# Altium schematic sheet 5-Bank3-5.schdoc(5-Bank3-5)
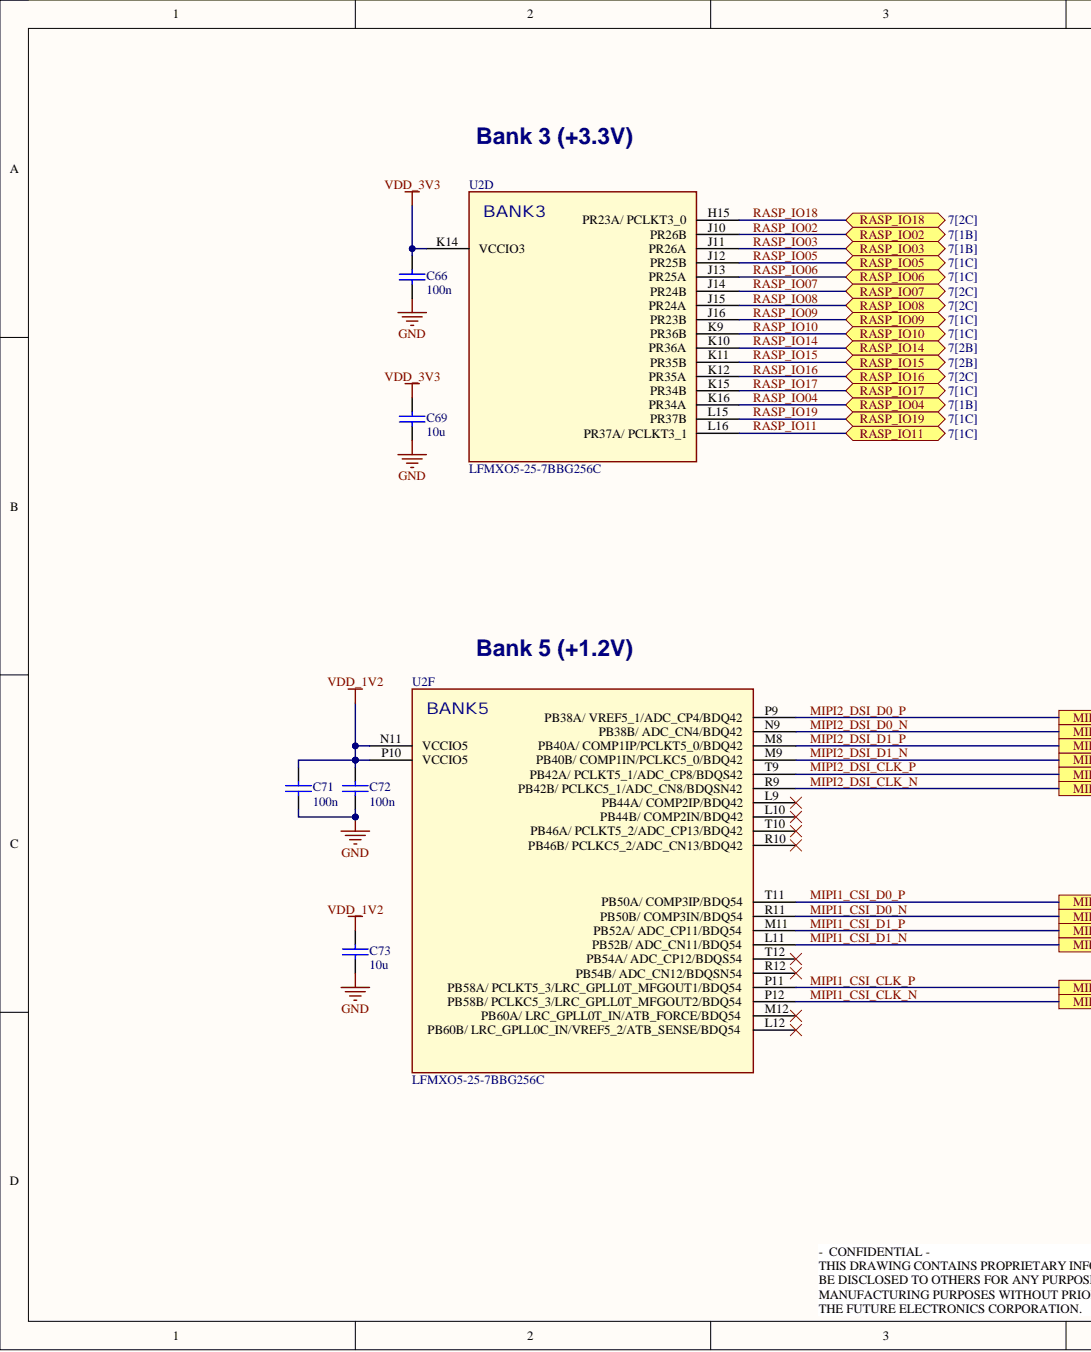
[diagram p0: 5-Bank3-5.schdoc, left]
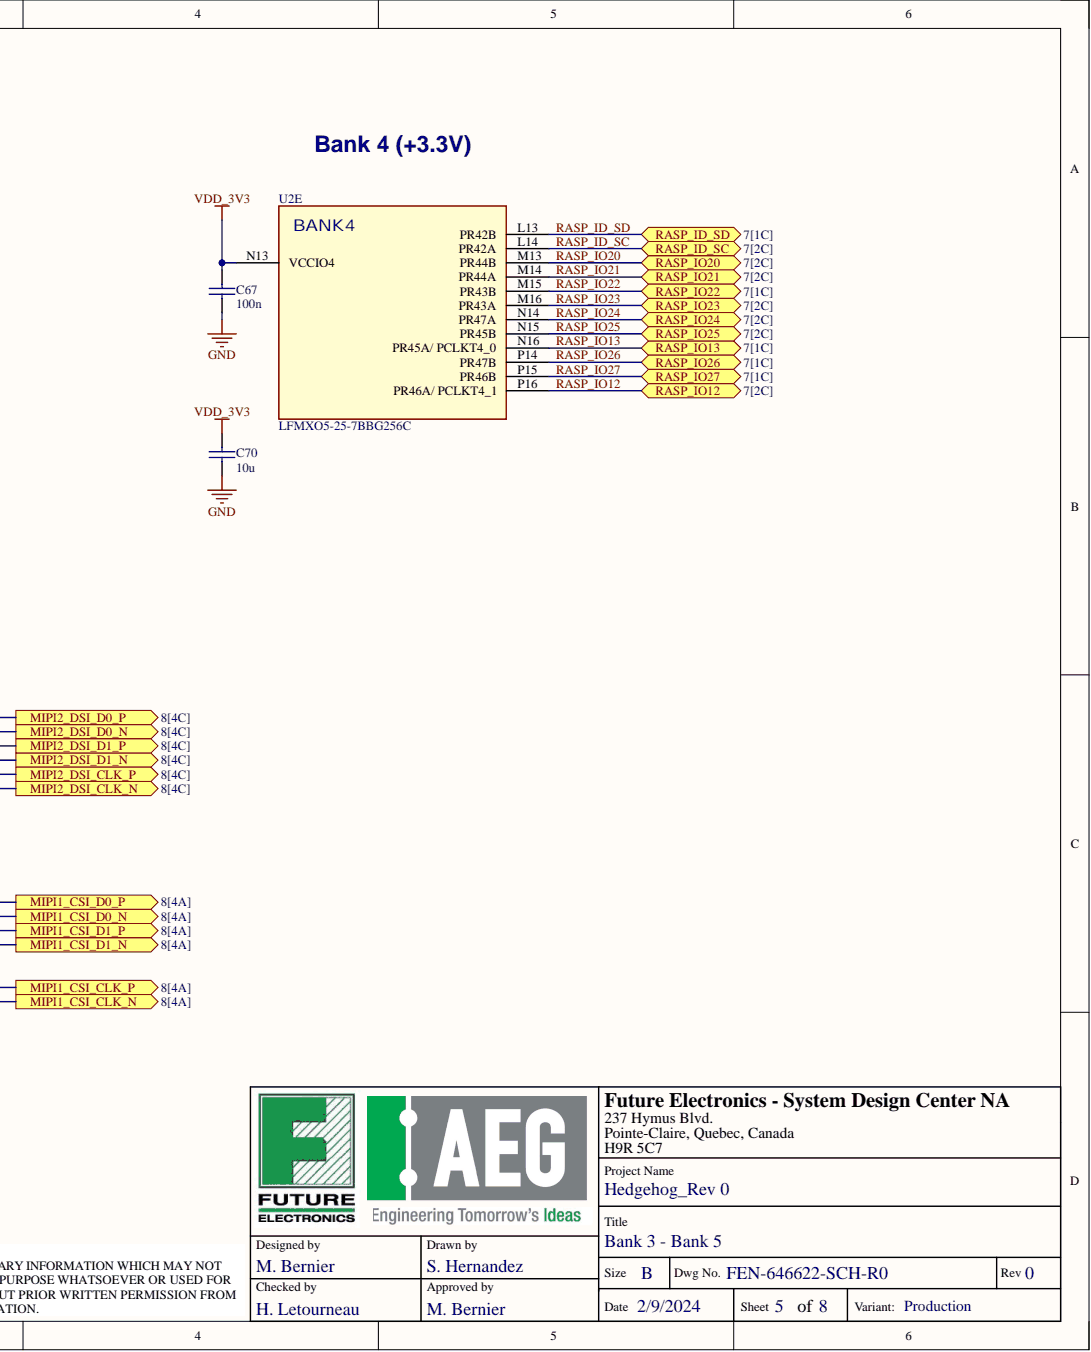
[diagram p1: 5-Bank3-5.schdoc, right]

## Parts
C66  value 100n  [0402]  (hidden comment: C-100nF-50V-Cer-0402)
C67  value 100n  [0402]  (hidden comment: C-100nF-50V-Cer-0402)
C69  value 10u  [1206]  (hidden comment: C-10uF-50V-Cer-1206_A)
C70  value 10u  [1206]  (hidden comment: C-10uF-50V-Cer-1206_A)
C71  value 100n  [0402]  (hidden comment: C-100nF-50V-Cer-0402)
C72  value 100n  [0402]  (hidden comment: C-100nF-50V-Cer-0402)
C73  value 10u  [1206]  (hidden comment: C-10uF-50V-Cer-1206_A)
U2  MFR PN: LFMXO5-25-7BBG256C  [BBG256_LATTICE]  (hidden comment: U-LFMXO5-25-7BBG256C)  (parts D,E,F)

## Ports
MIPI1_CSI_CLK_N, MIPI1_CSI_CLK_P, MIPI1_CSI_D0_N, MIPI1_CSI_D0_P, MIPI1_CSI_D1_N, MIPI1_CSI_D1_P, MIPI2_DSI_CLK_N, MIPI2_DSI_CLK_P, MIPI2_DSI_D0_N, MIPI2_DSI_D0_P, MIPI2_DSI_D1_N, MIPI2_DSI_D1_P, RASP_ID_SC, RASP_ID_SD, RASP_IO02, RASP_IO03, RASP_IO04, RASP_IO05, RASP_IO06, RASP_IO07, RASP_IO08, RASP_IO09, RASP_IO10, RASP_IO11, RASP_IO12, RASP_IO13, RASP_IO14, RASP_IO15, RASP_IO16, RASP_IO17, RASP_IO18, RASP_IO19, RASP_IO20, RASP_IO21, RASP_IO22, RASP_IO23, RASP_IO24, RASP_IO25, RASP_IO26, RASP_IO27

## Nets
GND: C66.2 C67.2 C69.2 C70.2 C71.2 C72.2 C73.2
VDD_1V2: C71.1 C72.1 C73.1 U2.N11(VCCIO5) U2.P10(VCCIO5)
VDD_3V3: C66.1 C67.1 C69.1 C70.1 U2.K14(VCCIO3) U2.N13(VCCIO4)
MIPI1_CSI_CLK_N: U2.P12(PB58B/_PCLKC5_3/LRC_GPLL0T_MFGOUT2/BDQ54) ; port MIPI1_CSI_CLK_N
MIPI1_CSI_CLK_P: U2.P11(PB58A/_PCLKT5_3/LRC_GPLL0T_MFGOUT1/BDQ54) ; port MIPI1_CSI_CLK_P
MIPI1_CSI_D0_N: U2.R11(PB50B/_COMP3IN/BDQ54) ; port MIPI1_CSI_D0_N
MIPI1_CSI_D0_P: U2.T11(PB50A/_COMP3IP/BDQ54) ; port MIPI1_CSI_D0_P
MIPI1_CSI_D1_N: U2.L11(PB52B/_ADC_CN11/BDQ54) ; port MIPI1_CSI_D1_N
MIPI1_CSI_D1_P: U2.M11(PB52A/_ADC_CP11/BDQ54) ; port MIPI1_CSI_D1_P
MIPI2_DSI_CLK_N: U2.R9(PB42B/_PCLKC5_1/ADC_CN8/BDQSN42) ; port MIPI2_DSI_CLK_N
MIPI2_DSI_CLK_P: U2.T9(PB42A/_PCLKT5_1/ADC_CP8/BDQS42) ; port MIPI2_DSI_CLK_P
MIPI2_DSI_D0_N: U2.N9(PB38B/_ADC_CN4/BDQ42) ; port MIPI2_DSI_D0_N
MIPI2_DSI_D0_P: U2.P9(PB38A/_VREF5_1/ADC_CP4/BDQ42) ; port MIPI2_DSI_D0_P
MIPI2_DSI_D1_N: U2.M9 ; port MIPI2_DSI_D1_N
MIPI2_DSI_D1_P: U2.M8 ; port MIPI2_DSI_D1_P
RASP_ID_SC: U2.L14(PR42A) ; port RASP_ID_SC
RASP_ID_SD: U2.L13(PR42B) ; port RASP_ID_SD
RASP_IO02: U2.J10(PR26B) ; port RASP_IO02
RASP_IO03: U2.J11(PR26A) ; port RASP_IO03
RASP_IO04: U2.K16(PR34A) ; port RASP_IO04
RASP_IO05: U2.J12(PR25B) ; port RASP_IO05
RASP_IO06: U2.J13(PR25A) ; port RASP_IO06
RASP_IO07: U2.J14(PR24B) ; port RASP_IO07
RASP_IO08: U2.J15(PR24A) ; port RASP_IO08
RASP_IO09: U2.J16(PR23B) ; port RASP_IO09
RASP_IO10: U2.K9(PR36B) ; port RASP_IO10
RASP_IO11: U2.L16(PR37A/_PCLKT3_1) ; port RASP_IO11
RASP_IO12: U2.P16(PR46A/_PCLKT4_1) ; port RASP_IO12
RASP_IO13: U2.N16(PR45A/_PCLKT4_0) ; port RASP_IO13
RASP_IO14: U2.K10(PR36A) ; port RASP_IO14
RASP_IO15: U2.K11(PR35B) ; port RASP_IO15
RASP_IO16: U2.K12(PR35A) ; port RASP_IO16
RASP_IO17: U2.K15(PR34B) ; port RASP_IO17
RASP_IO18: U2.H15(PR23A/_PCLKT3_0) ; port RASP_IO18
RASP_IO19: U2.L15(PR37B) ; port RASP_IO19
RASP_IO20: U2.M13(PR44B) ; port RASP_IO20
RASP_IO21: U2.M14(PR44A) ; port RASP_IO21
RASP_IO22: U2.M15(PR43B) ; port RASP_IO22
RASP_IO23: U2.M16(PR43A) ; port RASP_IO23
RASP_IO24: U2.N14(PR47A) ; port RASP_IO24
RASP_IO25: U2.N15(PR45B) ; port RASP_IO25
RASP_IO26: U2.P14(PR47B) ; port RASP_IO26
RASP_IO27: U2.P15(PR46B) ; port RASP_IO27

Not connected: U2 L9(PB44A/_COMP2IP/BDQ42) L10(PB44B/_COMP2IN/BDQ42) L12(PB60B/_LRC_GPLL0C_IN/VREF5_2/ATB_SENSE/BDQ54) M12(PB60A/_LRC_GPLL0T_IN/ATB_FORCE/BDQ54) R10(PB46B/_PCLKC5_2/ADC_CN13/BDQ42) R12(PB54B/_ADC_CN12/BDQSN54) T10(PB46A/_PCLKT5_2/ADC_CP13/BDQ42) T12(PB54A/_ADC_CP12/BDQS54)

## Library data of the parts
C66 C67 C71 C72 = C-100nF-50V-Cer-0402: Cap 0402 SM X7R 50V 10%; MFR: TDK; MFR PN: C1005X7R1H104K050BB; Future PN (CT): 04025C104KATN-CT; Future PN (TR): 04025C104KATN; Rating: 50V 10%; Component Kind: Standard; ComponentLink1Description: Datasheet; ComponentLink1URL: http://images.ihscontent.net/vipimages/VipMasterIC/IC/TDKC/TDKC-S-A0000443042/TDKC-S-A0000443042-1.pdf; Library Name: Future_Capacitors_V1.SCHLIB; Verified: AD042616
C69 C70 C73 = C-10uF-50V-Cer-1206_A: Cap 1206 SM X7R 50V 10%; MFR: SAMSUNG; MFR PN: CL31B106KBHNNNE; Future PN (CT): TBD; Future PN (TR): 12065C106KATN; Rating: 50V 10%; Component Kind: Standard; ComponentLink1Description: Datasheet; ComponentLink1URL: http://www.samsungsem.com/kr/support/product-search/mlcc/__icsFiles/afieldfile/2019/06/18/CL31B106KBHNNNE-19.pdf; Library Name: Future_Capacitors_V1.SCHLIB; Verified: AD112817
U2 = U-LFMXO5-25-7BBG256C: FPGA MachXO5-NX BBG256 SM 0.95~1.05Vcore 450MHz; MFR: LATTICE; Future PN (CT): TBD; VModel: MMDDYY; Component Kind: Standard; ComponentLink1Description: Datasheet; ComponentLink1URL: https://www.latticesemi.com/-/media/LatticeSemi/Documents/MachXO5-NX/FPGA-DS-02102-1-0-MachXO5-NX-Family.ashx?document_id=53488; Future PN (TR): TBD; Rating: 0.95~1.05Vcore 450MHz; Verified: TBD; Library Name: Hedgehog_Rev0.SchLib
